# Altium schematic sheet [03] - SCHEMATIC.SchDoc
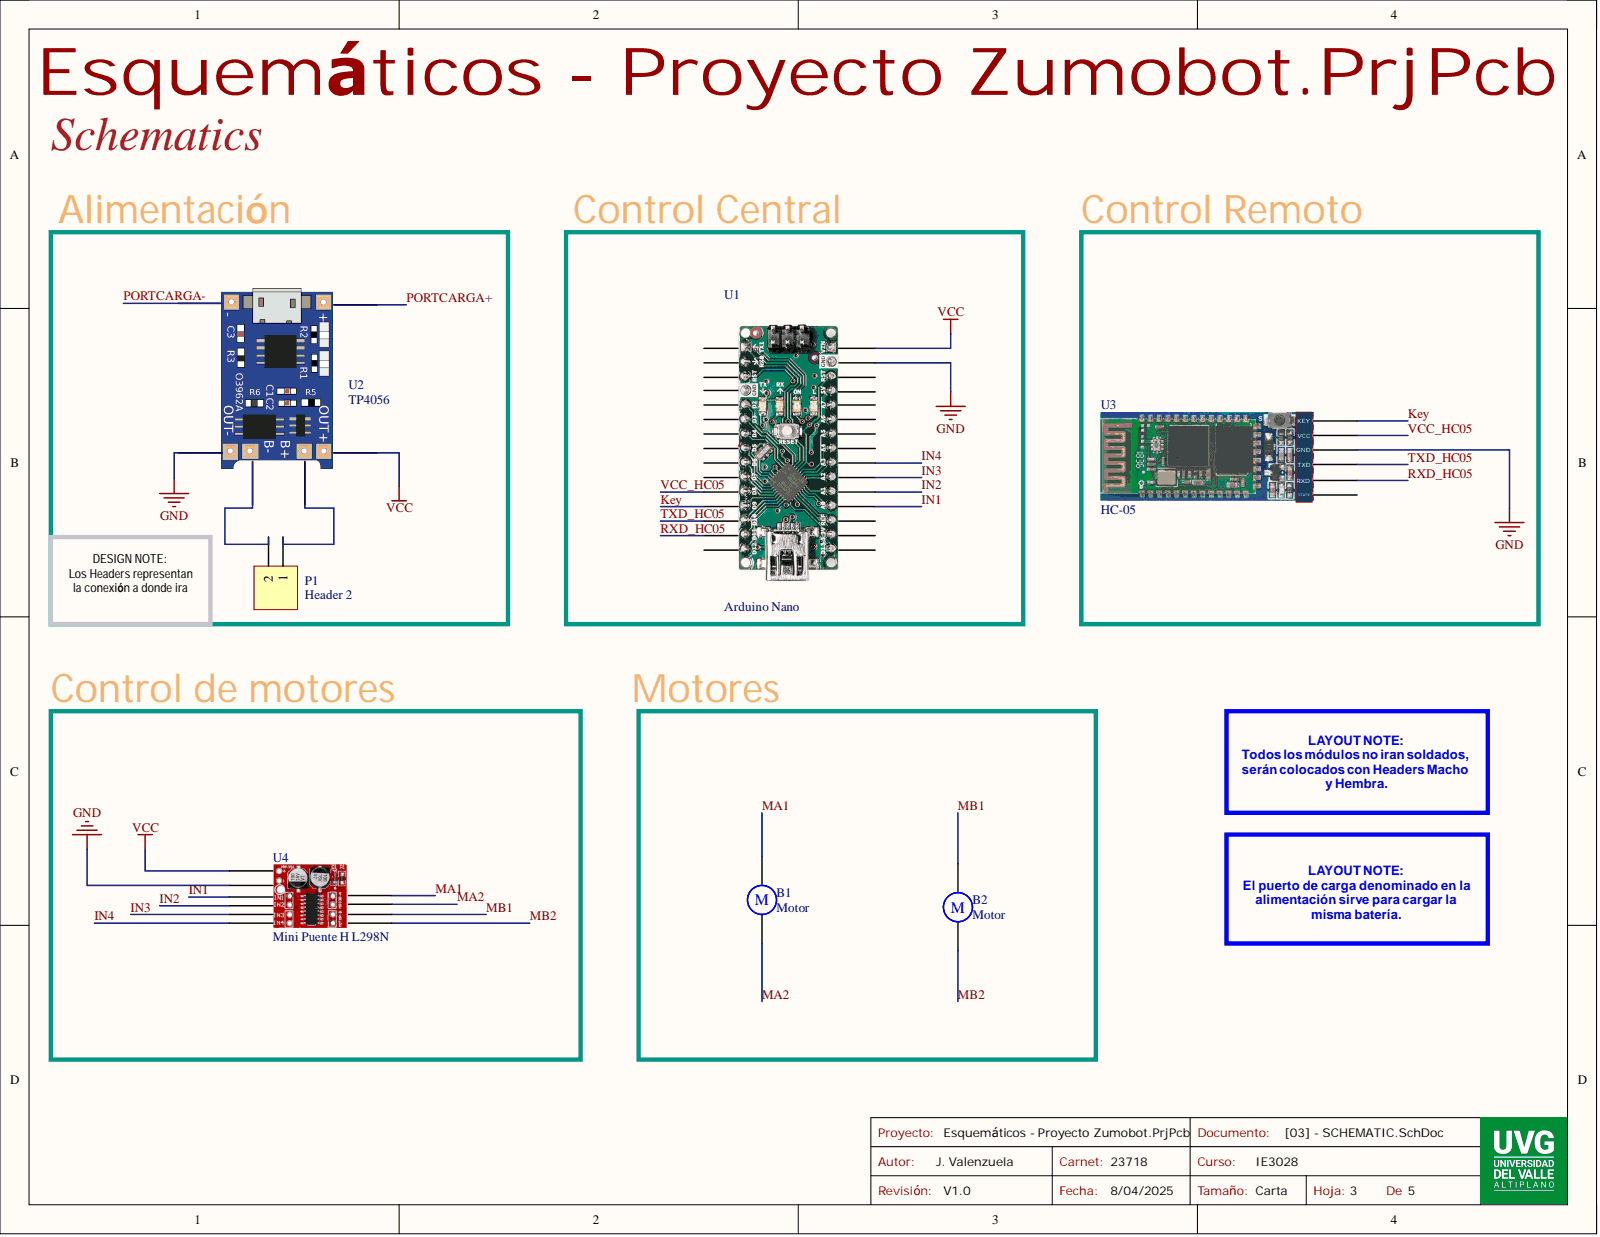
[diagram p0: [03] - SCHEMATIC.SchDoc]

## Parts
B1  Motor  [RB5-10.5]
B2  Motor  [RB5-10.5]
P1  Header 2  [HDR1X2]
U1  Arduino Nano  Manufacturer: Arduino  [Arduino Nano V3 + H]
U2  TP4056  [TP4056]
U3  HC-05  [HC-05]
U4  Mini Puente H L298N  [Mini Puente H L298N]

## Nets
GND: U1.29(GND) U2.6 U3.4(GND) U4.2
VCC: U1.30(VIN) U2.3 U4.1
IN1: U1.19(A0) U4.3
IN2: U1.20(A1) U4.4
IN3: U1.21(A2) U4.5
IN4: U1.22(A3) U4.6
Key: U1.12(D9) U3.6(KEY)
MA1: B1.1 U4.7
MA2: B1.2 U4.8
MB1: B2.1 U4.9
MB2: B2.2 U4.10
PORTCARGA+: U2.2
PORTCARGA-: U2.1
RXD_HC05: U1.14(D11) U3.2(RXD)
TXD_HC05: U1.13(D10) U3.3(TXD)
VCC_HC05: U1.11(D8) U3.5(VCC)
NetP1_1: P1.1 U2.4
NetP1_2: P1.2 U2.5

Not connected: U1 1(TX1) 2(RX0) 3(RST) 4(GND) 5(D2) 6(D3) 7(D4) 8(D5) 9(D6) 10(D7) 15(D12) 16(D13) 17(3V3) 18(REF) 23(A4) 24(A5) 25(A6) 26(A7) 27(5V) 28(RST); U3 1(STATE)

## Library data of the parts
B1 B2 = Motor: Motor, General Kind; Component Kind: Standard; LatestRevisionDate: 17-Jul-2002; LatestRevisionNote: Re-released for DXP Platform.; Library Name: Miscellaneous Devices.IntLib; PackageDescription: Capacitor (Radial); 2 Leads; PackageReference: RB5-10.5; Published: 24-Mar-1999; Publisher: Altium Limited
P1 = Header 2: Header, 2-Pin; Component Kind: Standard; LatestRevisionDate: 17-Jul-2002; LatestRevisionNote: Re-released for DXP Platform.; Library Name: Miscellaneous Connectors.IntLib; Signal Integrity: Connector; Publisher: Altium Limited
U1 = Arduino Nano: ATmega328 Microcontroller Development Board 16MHz CPU 2KB RAM 1KB/32KB EEPROM/Flash; Manufacturer Part Number: A000005; Core Architecture: AVR; Data Bus Width: 8b; Evaluation Kit: Yes; Max Supply Voltage: 12V; Memory Format: FLASH; Memory Size: 32KB; Min Supply Voltage: 7V; Nominal Supply Current: 19mA; Number of I/Os: 22; Category: DSPs - Digital Signal Processors; Component Kind: Standard; ComponentLink1Description: https://datasheet.ciiva.com/2398/arduinonanomanual23-2398236.pdf?src-supplier=Digikey; ComponentLink1URL: https://datasheet.ciiva.com/2398/arduinonanomanual23-2398236.pdf?src-supplier=Digikey; ComponentLink2Description: https://datasheet.ciiva.com/1336/1682238-1336473.pdf?src-supplier=Element14; ComponentLink2URL: https://datasheet.ciiva.com/1336/1682238-1336473.pdf?src-supplier=Element14; ComponentLink3Description: https://datasheet.ciiva.com/1414/810078-1414124.pdf?src-supplier=Newark; ComponentLink3URL: https://datasheet.ciiva.com/1414/810078-1414124.pdf?src-supplier=Newark; ComponentLink4Description: https://datasheet.ciiva.com/19455/2121148-19455443.pdf?src-supplier=Jameco; ComponentLink4URL: https://datasheet.ciiva.com/19455/2121148-19455443.pdf?src-supplier=Jameco; ComponentLink5Description: https://datasheet.ciiva.com/4926/arduino-arduinoboardnano-188748-4926316.pdf?src-supplier=Mouser; ComponentLink5URL: https://datasheet.ciiva.com/4926/arduino-arduinoboardnano-188748-4926316.pdf?src-supplier=Mouser; Frequency: 16MHz; Library Name: Arduino Nano.IntLib; Operating Supply Voltage: 12V; Package Quantity: 50; Pricing: 1=24.9 (USD); RoHS: Yes; Series: AVR® ATmega; Stock: 5414; Supplier: Digikey; Supplier Part Number: 1050-1001-ND; Weight: 0.358383oz
U2 = TP4056: IC Socket, 16 Contact(s), 2.54mm Term Pitch, 1.014inch Row Spacing, Surface Mount; Manufacturer: mikroElektronika; Category: LDO; Component Kind: Standard; Library Name: arduino.IntLib; Manufacturer Part Number: MIKROE-4449; Pricing: 1=20, 10=20, 50=20, 100=20, 1000=20, 10000=20 (USD); RoHS: No; Stock: 6; Supplier: Mouser; Supplier 1: Mouser; Supplier Part Number: 932-MIKROE-4449; Supplier Part Number 1: 932-MIKROE-4449
U3 = BLUETOOTH HC-05: Módulo Bluetooth que permite comunicación inalámbrica mediante UART, configurable como maestro o esclavo; Manufacturer: HC; Core Architecture: Bluetooth 2.0; Data Bus Width: UART (Serial); Frequency: 2.4 GHz; Max Supply Voltage: 6V; Min Supply Voltage: 3.3V; Nominal Supply Current: 30mA; Number of I/Os: 4 (RX, TX, VCC, GND); Operating Supply Voltage: 3.3V - 6V; Category: Wireless Modules - Bluetooth; Component Kind: Standard; ComponentLink1Description: https://www.tgltechnologies.com/assets/data-sheets/Bluetooth%20HC05.pdf; ComponentLink1URL: https://www.tgltechnologies.com/assets/data-sheets/Bluetooth%20HC05.pdf; ComponentLink2Description: https://www.rhydolabz.com/documents/37/hc-05%20datasheet.pdf; ComponentLink2URL: https://www.rhydolabz.com/documents/37/hc-05%20datasheet.pdf; Library Name: Arduino.IntLib; Manufacturer Part Number: HC-05; Package Quantity: 1; Pricing: 1 = 5.00 (USD); RoHS: Yes; Series: HC Bluetooth Modules; Supplier: Digikey; Supplier Part: HC-05; Weight: 0.1 oz
U4 = Mini Puente H L298N: L298 Gate and Power Driver 5VDC to 7VDC Output Evaluation Board Automotive; Manufacturer: Seeed Studio; Manufacturer Part Number: 105990007; Motor Type: Stepper; Output Current: 2A; Category: Off-Board Modules; Component Kind: Standard; ComponentLink1Description: https://raw.githubusercontent.com/SeeedDocument/Grove-I2C_Motor_Driver_V1.3/master/res/L298datasheet.pdf?src-supplier=Digikey; ComponentLink1URL: https://raw.githubusercontent.com/SeeedDocument/Grove-I2C_Motor_Driver_V1.3/master/res/L298datasheet.pdf?src-supplier=Digikey; Library Name: Mini Puente H L298N.IntLib; Max Operating Temperature: 130°C; Min Operating Temperature: -25°C; Mounting Technology: Chassis Mount; Pricing: 1=23.5 (USD); Series: -; Stock: 5; Supplier: Digikey; Supplier Part Number: 1597-1470-ND; Weight: 0.106lb
